# Altium schematic sheet [04] - POWER HARVESTING SYSTEM.SchDoc("[04] - POWER HARVESTING SYSTEM")
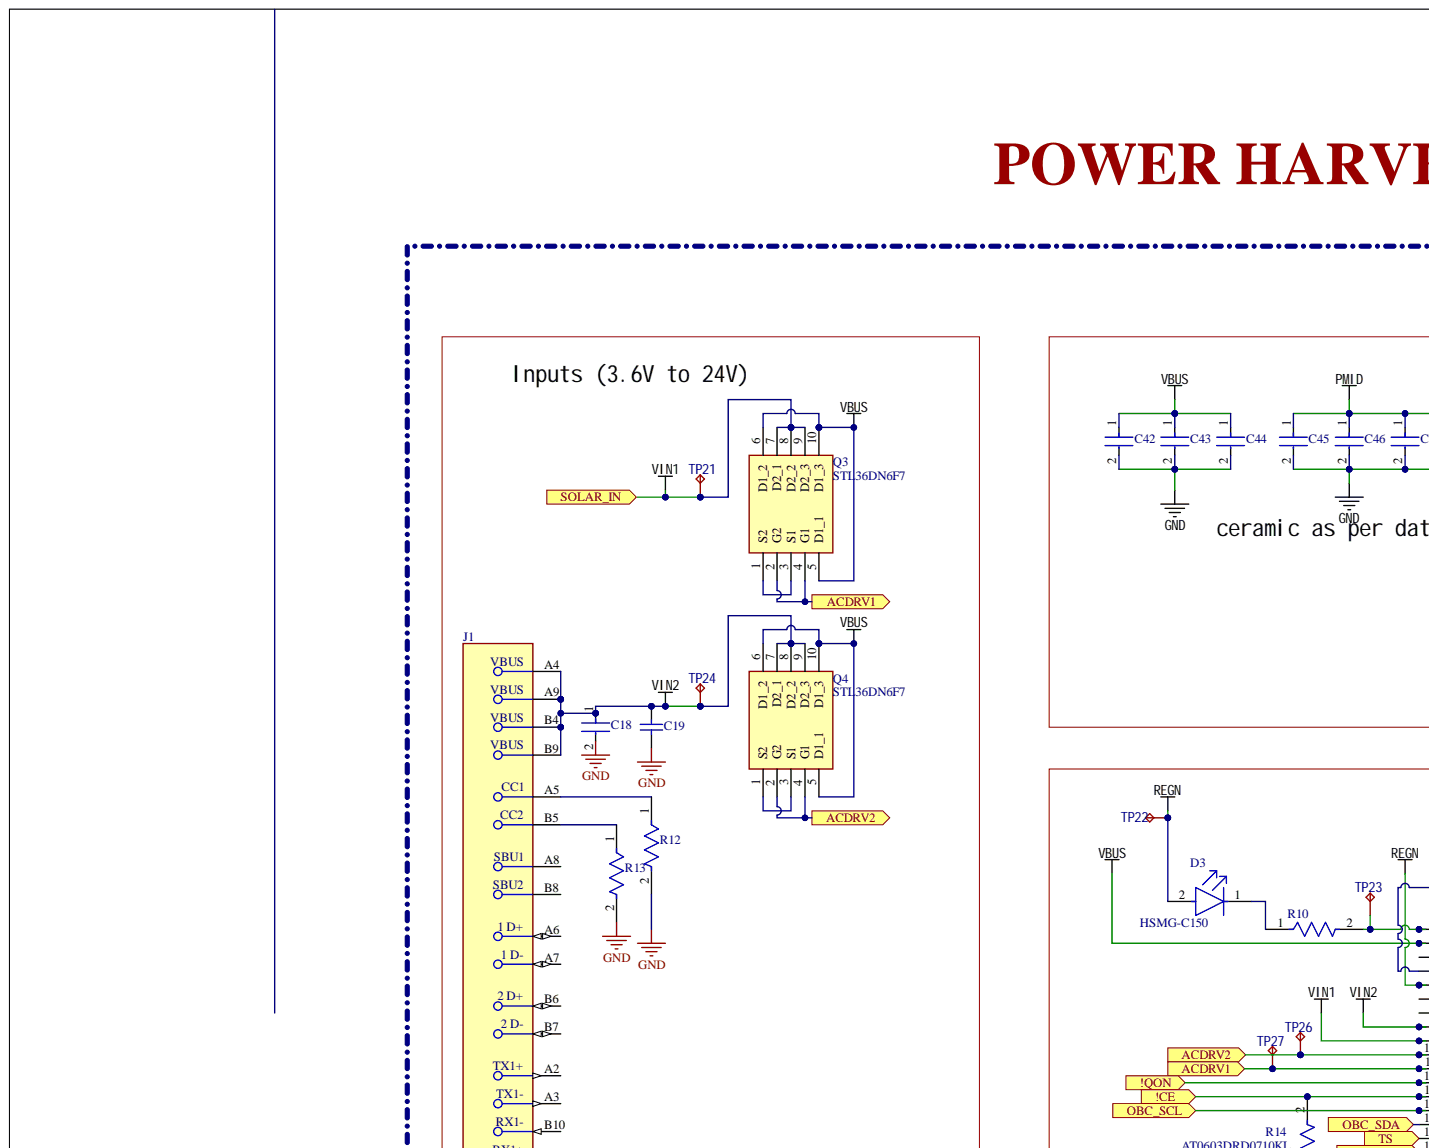
[diagram p0: [04] - POWER HARVESTING SYSTEM.SchDoc, top left]
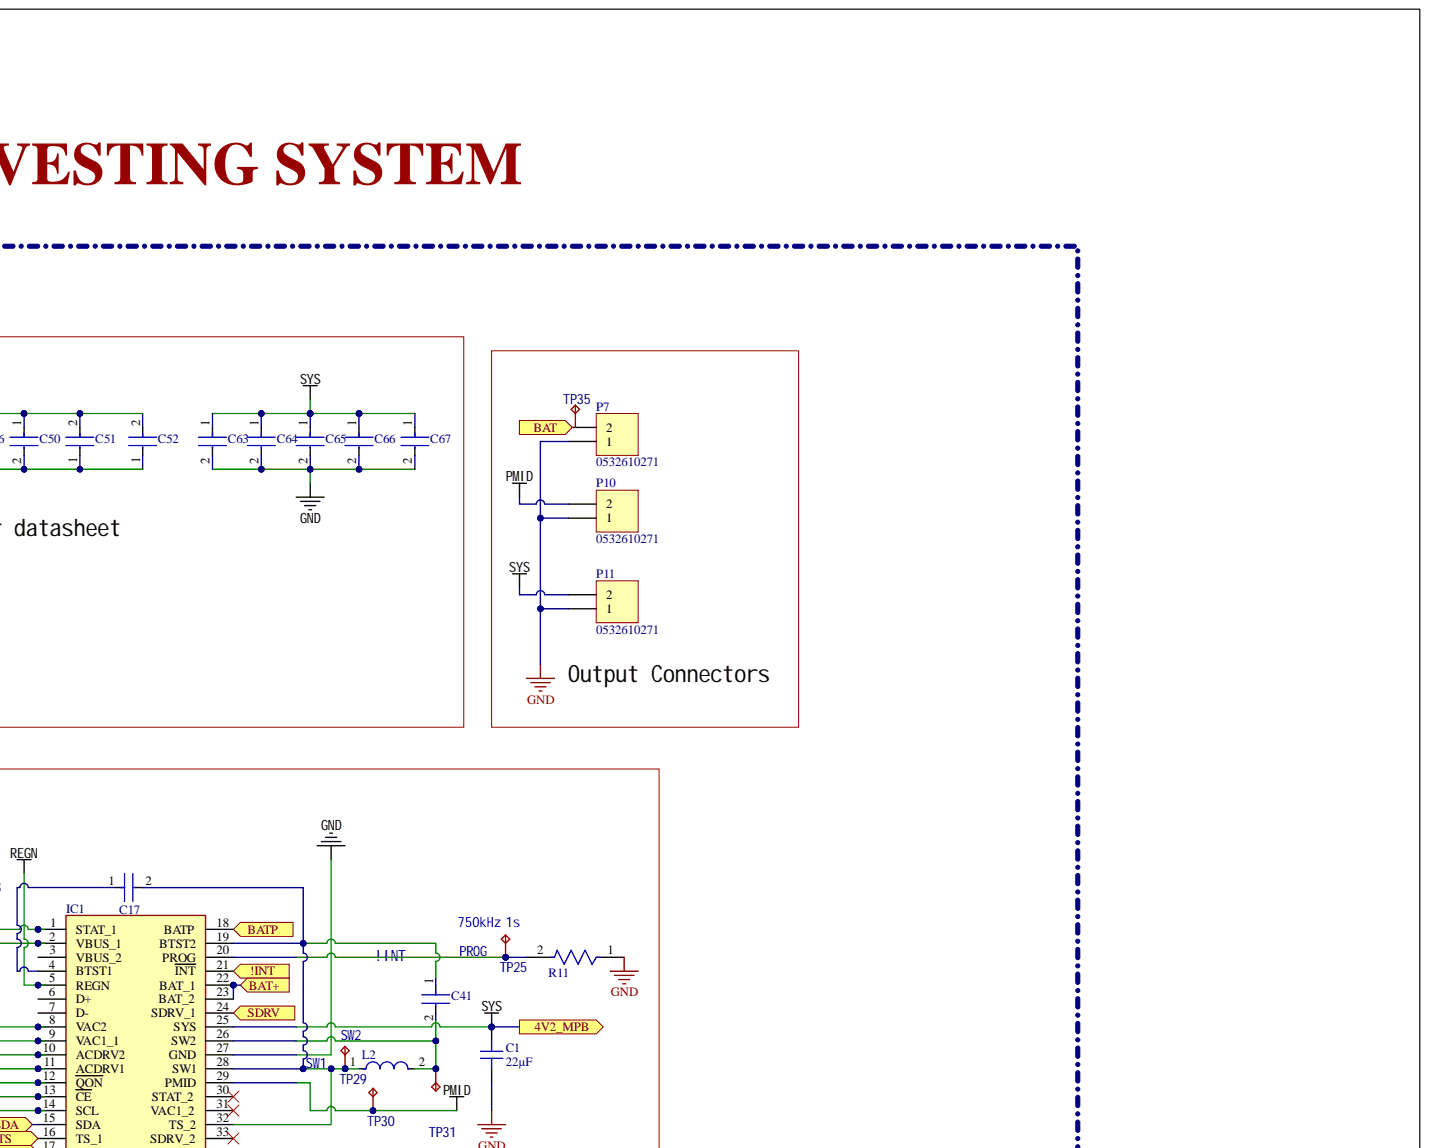
[diagram p1: [04] - POWER HARVESTING SYSTEM.SchDoc, top right]
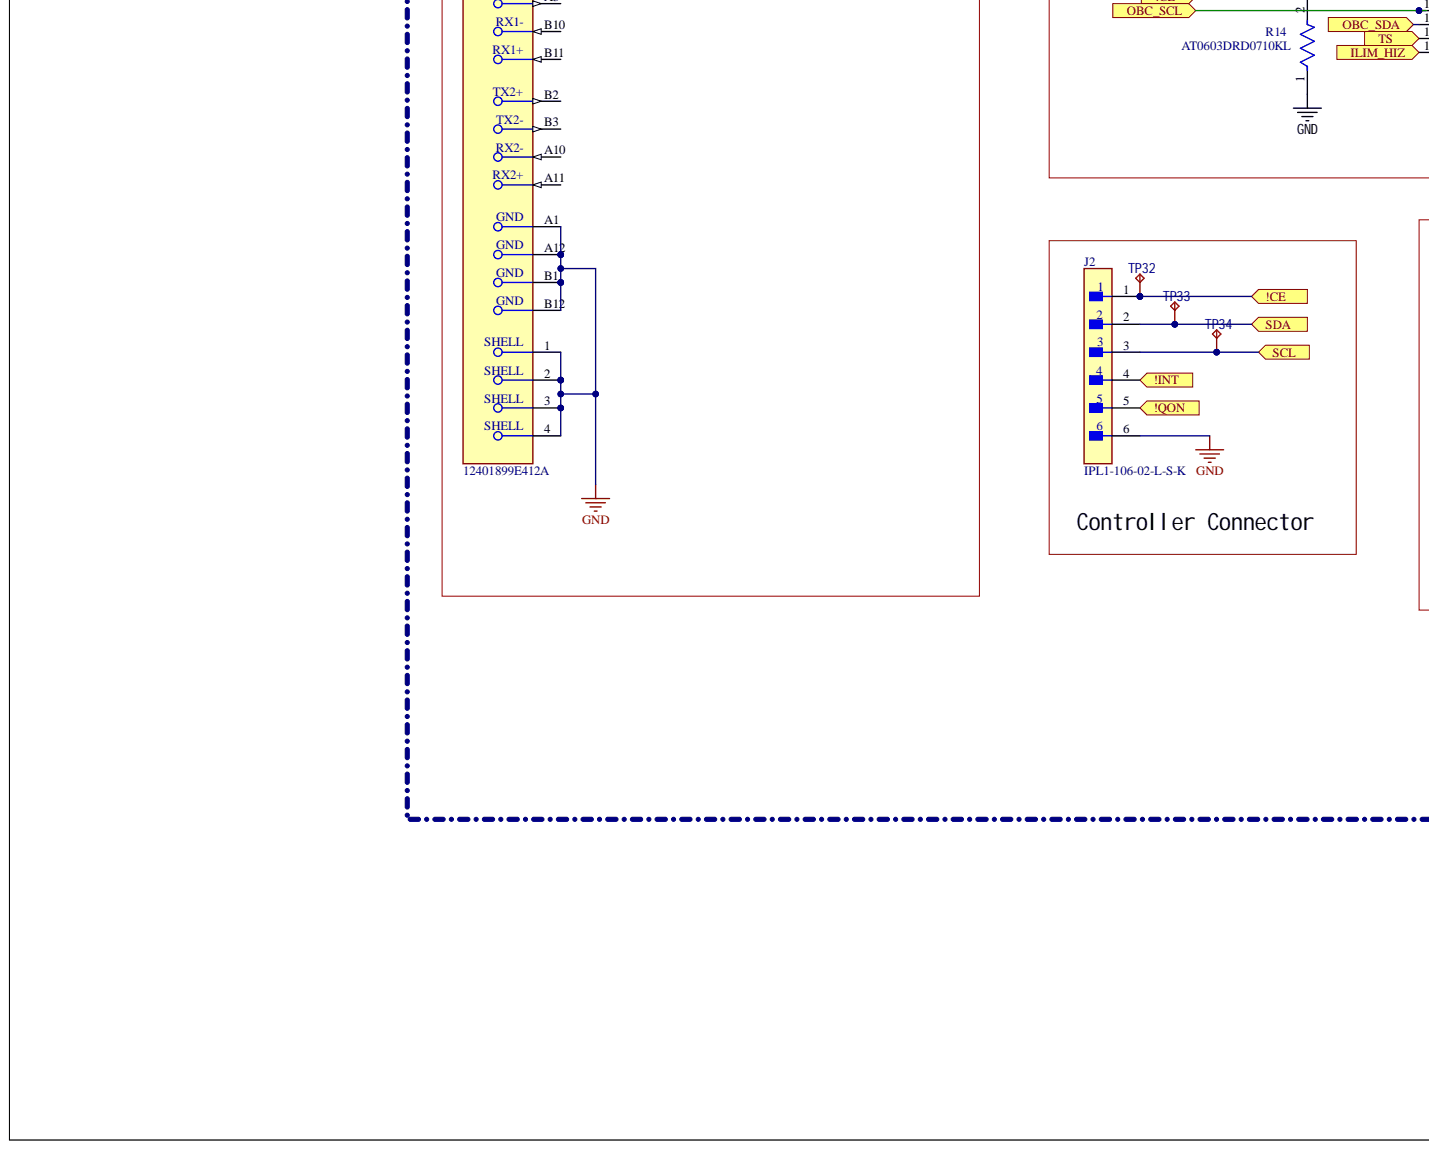
[diagram p2: [04] - POWER HARVESTING SYSTEM.SchDoc, bottom left]
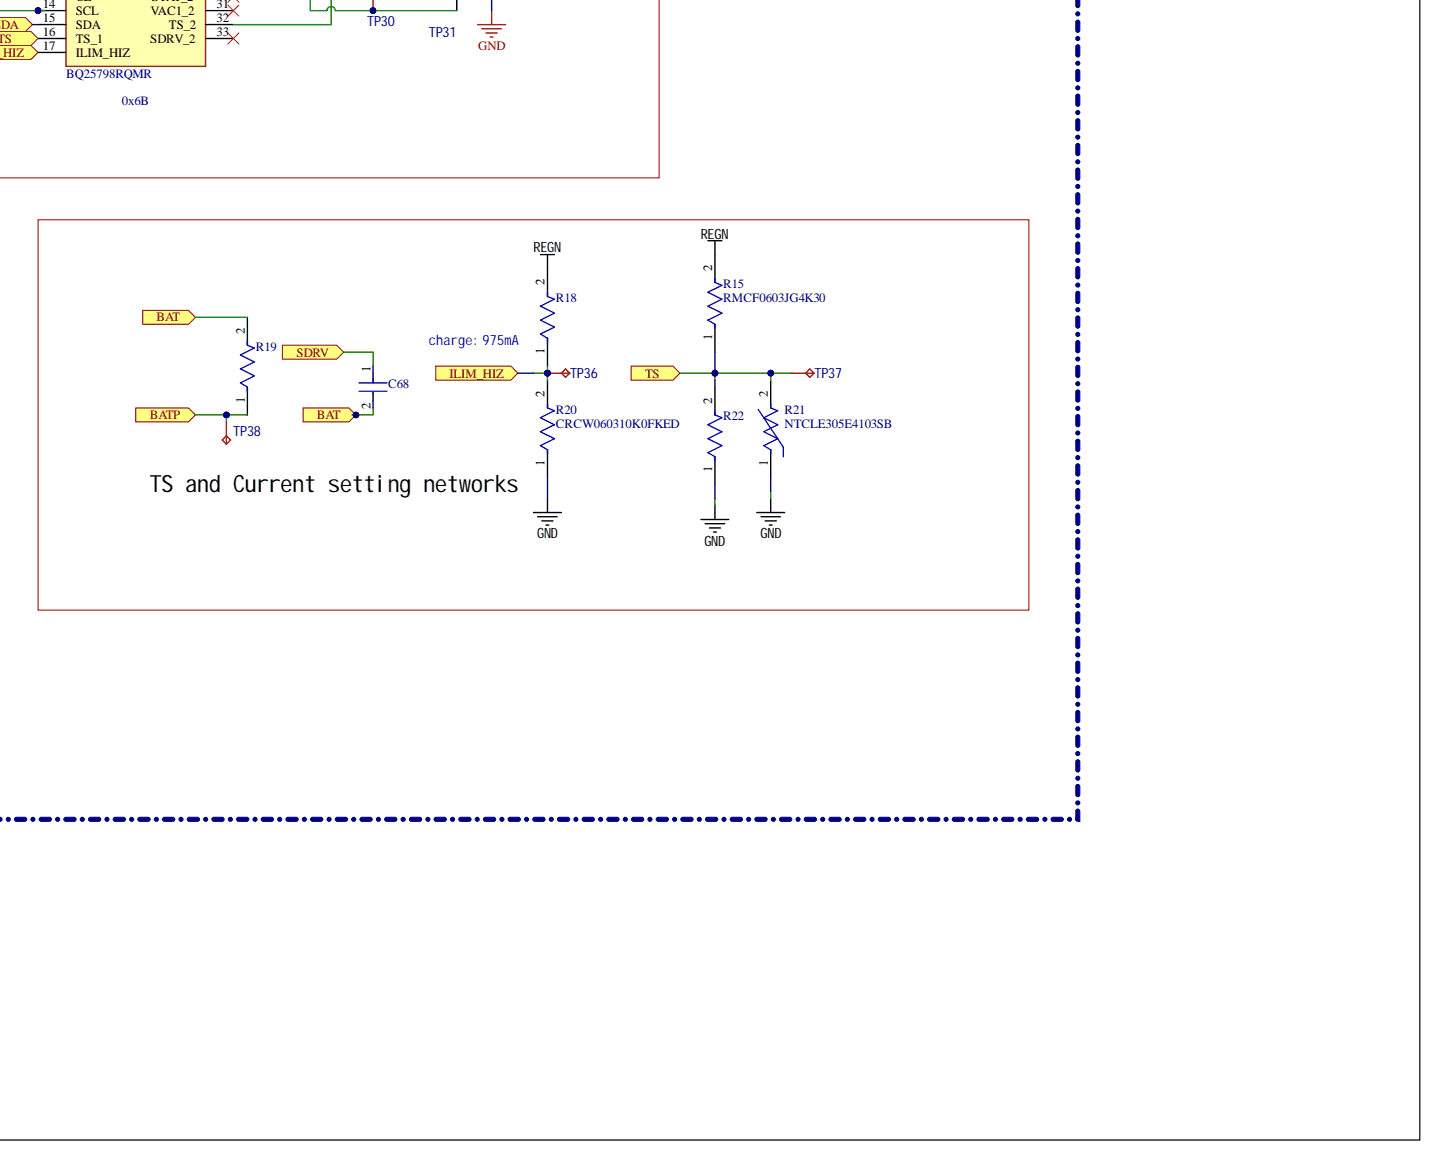
[diagram p3: [04] - POWER HARVESTING SYSTEM.SchDoc, bottom right]

## Parts
C1  Capacitance: 22µF  [CAP 0603/1608]  (hidden comment: GRM188R60J226MEA0D)
C17  CC0603KRX7R9BB473  [FP-CC0603-DA-MFG]  (value not drawn)
C18  ZRB15XC80G106ME05D  [FP-ZRB15X-0_22-MFG]  (value not drawn)
C19  C0603C104K4RALTU  [CAPC1608X87X35ML15T15]  (value not drawn)
C41  CC0603KRX7R9BB473  [FP-CC0603-DA-MFG]  (value not drawn)
C42  CL21A106KAYNNNE  [FP-CL21-IPC_A]  (value not drawn)
C43  CL21A106KAYNNNE  [FP-CL21-IPC_A]  (value not drawn)
C44  CC0603KRX7R9BB104  [FP-CC0603-DA-MFG]  (value not drawn)
C45  CL21A106KAYNNNE  [FP-CL21-IPC_A]  (value not drawn)
C46  CL21A106KAYNNNE  [FP-CL21-IPC_A]  (value not drawn)
C50  CC0603KRX7R9BB104  [FP-CC0603-DA-MFG]  (value not drawn)
C51  KRM55QR71E336KH01L  [FP-KRM55Q-6_1_5_3_3_9_1_2-MFG]  (value not drawn)
C52  KRM55QR71E336KH01L  [FP-KRM55Q-6_1_5_3_3_9_1_2-MFG]  (value not drawn)
C63  CL21A106KAYNNNE  [FP-CL21-IPC_A]  (value not drawn)
C64  CL21A106KAYNNNE  [FP-CL21-IPC_A]  (value not drawn)
C65  CL21A106KAYNNNE  [FP-CL21-IPC_A]  (value not drawn)
C66  CL21A106KAYNNNE  [FP-CL21-IPC_A]  (value not drawn)
C67  CC0603KRX7R9BB104  [FP-CC0603-DA-MFG]  (value not drawn)
C68  CC0603KRX7R9BB102  [FP-CC0603-DA-MFG]  (value not drawn)
D3  HSMG-C150  [FP-HSMG-C150-MFG]
IC1  BQ25798RQMR  [BQ25798RQMR]
J1  12401899E412A  [FP-12401899E412A-MFG]
J2  IPL1-106-02-L-S-K  [FP-IPL1-106-02-L-S-K-0]
L2  LQM21PN2R2MEHD  [FP-LQM21PN_EH-MFG]  (value not drawn)
P7  0532610271  [0532610271]
P10  0532610271  [0532610271]
P11  0532610271  [0532610271]
Q3  STL36DN6F7  [STL36DN6F7]
Q4  STL36DN6F7  [STL36DN6F7]
R10  RMCF0805JG2K00  [FP-RMCF0805-MFG]  (value not drawn)
R11  AT0603DRE074K7L  [FP-AT0603-IPC_C]  (value not drawn)
R12  MCR03EZPJ512  [FP-MCR03-IPC_B]  (value not drawn)
R13  MCR03EZPJ512  [FP-MCR03-IPC_B]  (value not drawn)
R14  AT0603DRD0710KL  [FP-AT0603-IPC_B]
R15  RMCF0603JG4K30  [FP-RMCF0603-IPC_A]
R18  RMCF0805JG18K0  [FP-RMCF0805-IPC_B]  (value not drawn)
R19  RMCF0805FG100R  [FP-RMCF0805-MFG]  (value not drawn)
R20  CRCW060310K0FKED  [FP-CRCW0603-e3-IPC_A]
R21  NTCLE305E4103SB  [FP-NTCLE305E4103SB-MFG]
R22  RMCF0805JG18K0  [FP-RMCF0805-IPC_B]  (value not drawn)
TP21  TP
TP22  TP
TP23  TP
TP24  TP
TP25  TP
TP26  TP
TP27  TP
TP29  TP
TP30  TP
TP31  TP
TP32  TP
TP33  TP
TP34  TP
TP35  TP
TP36  TP
TP37  TP
TP38  TP

## Ports
4V2_MPB, !CE, !INT, !QON, ACDRV1, ACDRV2, BAT, BAT+, BATP, ILIM_HIZ, OBC_SCL, OBC_SDA, SCL, SDA, SDRV, SOLAR_IN, TS

## Nets
GND: C1.1 C18.2 C19.1 C42.2 C43.2 C44.2 C45.2 C46.2 C50.2 C51.1 C52.1 C63.2 C64.2 C65.2 C66.2 C67.2 IC1.27(GND) J1.1 J1.2 J1.3 J1.4 J1.A1 J1.A12 J1.B1 J1.B12 J2.6 P7.1 P10.1 P11.1 R11.1 R12.2 R13.2 R14.1 R20.1 R21.1 R22.1
PMID: C45.1 C46.1 C50.1 C51.2 C52.2 IC1.29(PMID) P10.2 TP30.1
REGN: D3.2 IC1.5(REGN) R15.2 R18.2 TP22.1
VBUS: C42.1 C43.1 C44.1 IC1.2(VBUS_1) Q3.5(D1_1) Q3.6(D1_2) Q3.10(D1_3) Q4.5(D1_1) Q4.6(D1_2) Q4.10(D1_3)
VIN1: IC1.9(VAC1_1) Q3.7(D2_1) Q3.8(D2_2) Q3.9(D2_3) TP21.1
VIN2: C18.1 C19.2 IC1.8(VAC2) J1.A4 J1.A9 J1.B4 J1.B9 Q4.7(D2_1) Q4.8(D2_2) Q4.9(D2_3) TP24.1
!CE: IC1.13(CE) J2.1 R14.2 TP32.1 ; port !CE
!INT: IC1.21(INT) J2.4 ; port !INT
!QON: IC1.12(QON) J2.5 ; port !QON
ACDRV1: IC1.11(ACDRV1) Q3.2(G2) Q3.4(G1) TP27.1 ; port ACDRV1
ACDRV2: IC1.10(ACDRV2) Q4.2(G2) Q4.4(G1) TP26.1 ; port ACDRV2
BAT: C68.2 R19.2 ; port BAT
BAT+: IC1.22(BAT_1) IC1.23(BAT_2) ; port BAT+
BATP: IC1.18(BATP) R19.1 TP38.1 ; port BATP
ILIM_HIZ: IC1.17(ILIM_HIZ) R18.1 R20.2 TP36.1 ; port ILIM_HIZ
OBC_SCL: IC1.14(SCL) ; port OBC_SCL
OBC_SDA: IC1.15(SDA) ; port OBC_SDA
SCL: J2.3 TP34.1 ; port SCL
SDA: J2.2 TP33.1 ; port SDA
SDRV: C68.1 IC1.24(SDRV_1) ; port SDRV
SYS: C1.2 C63.1 C64.1 C65.1 C66.1 C67.1 IC1.25(SYS) P11.2 ; port 4V2_MPB
TS: IC1.16(TS_1) R15.1 R21.2 R22.2 TP37.1 ; port TS
NetC17_1: C17.1 IC1.4(BTST1)
NetC17_2: C17.2 C41.1 IC1.19(BTST2) IC1.28(SW1) IC1.32(TS_2) L2.1 TP29.1
NetC41_2: C41.2 IC1.26(SW2) L2.2 TP31.1
NetD3_1: D3.1 R10.1
NetIC1_1: IC1.1(STAT_1) R10.2 TP23.1
NetIC1_20: IC1.20(PROG) R11.2 TP25.1
NetJ1_A5: J1.A5 R12.1
NetJ1_B5: J1.B5 R13.1
NetQ3_1: Q3.1(S2) Q3.3(S1)
NetQ4_1: Q4.1(S2) Q4.3(S1)
!INT:
N00001: ; port SOLAR_IN
PROG:
SW1:
SW2:

Not connected: IC1 3(VBUS_2) 6(D+) 7(D-) 30(STAT_2) 31(VAC1_2) 33(SDRV_2); J1 A2 A3 A6 A7 A8 A10 A11 B2 B3 B6 B7 B8 B10 B11; P7 2; TP35 1

## Library data of the parts
C1 = GRM188R60J226MEA0D: CAP CER 22UF 6.3V X5R 0603; Manufacturer: Murata; Tolerance: 20%; Case Code (Imperial): 0603; Case Code (Metric): 1608; Case/Package: 0603; Component Kind: Standard; ComponentLink1Description: https://datasheet.ciiva.com/29540/partnumbering-e-01-29540441.pdf?src-supplier=Digi-Key; ComponentLink1URL: https://datasheet.ciiva.com/29540/partnumbering-e-01-29540441.pdf?src-supplier=Digi-Key; ComponentLink2Description: https://datasheet.ciiva.com/29540/partnumbering-e-01-29540442.pdf?src-supplier=Digi-Key; ComponentLink2URL: https://datasheet.ciiva.com/29540/partnumbering-e-01-29540442.pdf?src-supplier=Digi-Key; ComponentLink3Description: https://datasheet.ciiva.com/29540/partnumbering-e-01-29540443.pdf?src-supplier=Digi-Key; ComponentLink3URL: https://datasheet.ciiva.com/29540/partnumbering-e-01-29540443.pdf?src-supplier=Digi-Key; Depth: 0.8mm; Dielectric: X5R; Height: 0.8mm; Lead Free: Lead Free; Length: 1.6mm; Manufacturer Part Number: GRM188R60J226MEA0D; Max Operating Temperature: 85°C; Min Operating Temperature: -55°C; Mount: Surface Mount; Package Quantity: 4000; Packaging: Tape and Reel; Pricing 1: 1 = 0.26000, 10 = 0.17700, 100 = 0.10010, 500 = 0.07066, 1000 = 0.06182 (USD); REACH SVHC: No SVHC; RoHS: Yes; Stock 1: 6592419; Supplier 1: Digi-Key; Supplier Part Number 1: 490-7611-1-ND; Termination: SMD/SMT; Thickness: 0.039inch; Voltage Rating: 6.3V; Voltage Rating (DC): 6.3V; Weight: 0.000222oz; Width: 0.8mm; Library Name: UVG.IntLib
C17 C41 = CMP-2100-03604-2: CAP CER 0.047UF 50V X7R 0603; Manufacturer: YAGEO; Capacitance: 47 nF; Material: Ceramic; Temperature Coefficient: 15%; Tolerance: 0.1; Value: 47 nF; Automotive: No; Category: Cap; Component Kind: Standard; ComponentLink2Description: Datasheet; ComponentLink2URL: http://www.yageo.com/documents/recent/UPY-GPHC_X7R_6.3V-to-50V_18.pdf; Device Class L1: Passive Components; Device Class L2: Capacitors; Device Class L3: Ceramic Capacitors; Digikey Description: CAP CER 0.047UF 50V X7R 0603; Footprint Url: http://www.yageo.com/exep/pages/download/literatures/UPY-C_GEN_24.pdf; Height: 0.9mm; Lead Free: Yes; Library Name: Altium Content Vault; Manufacturer Part Number: CC0603KRX7R9BB473; Mouser Description: Multilayer Ceramic Capacitors MLCC - SMD/SMT .047UF 50V 10% 0603; Octopart: https://octopart.com/cc0603krx7r9bb473-yageo-9292680; Package: CC0603-DA; RoHS: TRUE; Temperature: -55°C to +125°C; Temperature Characteristic: X7R; Temperature Range High: +125°C; Temperature Range Low: -55°C; Voltage: 50 V; Voltage Rating: 50 V
C18 = CMP-06035-063245-1: Multilayer Ceramic Capacitors on Interposer Board for General Purpose 10μF ±20% 4VDC X6S  SMD  0402; Component Kind: Standard; Library Name: Altium Content Vault
C19 = CMP-2006-03059-1: Manufacturer: Kemet; Component Kind: Standard; Library Name: Altium Content Vault; Part Number: C0603C104K4RALTU
C42 C43 C45 C46 C63-C66 = CMP-13271-002975-1: CL21 Series 0805 10uF 25V ±10% Tolerance X5R Multilayer Ceramic Chip Capacitor; Capacitance: 10uF; Case Code (Imperial): 0805; Case Code (Metric): 2012; Case/Package: 0805; Component Kind: Standard; Depth: 1.25mm; Dielectric: X5R; Height: 1.25mm; Length: 2mm; Library Name: Altium Content Vault; Max Operating Temperature: 85°C; Min Operating Temperature: -55°C; Mount: Surface Mount; Package Quantity: 1; Packaging: Tape and Reel; Radiation Hardening: No; Thickness: 0.057inch; Tolerance: 10%; Voltage Rating: 25V; Width: 0.049inch
C44 C50 C67 = CMP-2100-03601-2: CAP CER 0.1UF 50V X7R 0603; Manufacturer: YAGEO; Capacitance: 100 nF; Material: Ceramic; Temperature Coefficient: 15%; Tolerance: 0.1; Value: 100 nF; Automotive: No; Category: Cap; Component Kind: Standard; ComponentLink2Description: Datasheet; ComponentLink2URL: http://www.yageo.com/documents/recent/UPY-GPHC_X7R_6.3V-to-50V_18.pdf; Device Class L1: Passive Components; Device Class L2: Capacitors; Device Class L3: Ceramic Capacitors; Digikey Description: CAP CER 0.1UF 50V X7R 0603; Footprint Url: http://www.yageo.com/exep/pages/download/literatures/UPY-C_GEN_24.pdf; Height: 0.9mm; Lead Free: Yes; Library Name: Altium Content Vault; Manufacturer Part Number: CC0603KRX7R9BB104; Mouser Description: Multilayer Ceramic Capacitor, CC Series, 0.1 - F, - 10%, X7R, 50 V, 0603 [1608 Metric]; Octopart: https://octopart.com/cc0603krx7r9bb104-yageo-42244635; Package: CC0603-DA; RoHS: TRUE; Temperature: -55°C to +125°C; Temperature Characteristic: X7R; Temperature Range High: +125°C; Temperature Range Low: -55°C; Voltage: 50 V; Voltage Rating: 50 V
C51 C52 = CMP-06035-051390-1: Cap Ceramic 33uF 25V X7R ±10% SMD 2220 +125°C Emboss T/R; Component Kind: Standard; Library Name: Altium Content Vault
C68 = CMP-2100-03599-2: CAP CER 1000PF 50V X7R 0603; Manufacturer: YAGEO; Capacitance: 1.0 nF; Material: Ceramic; Temperature Coefficient: 15%; Tolerance: 0.1; Value: 1.0 nF; Automotive: No; Category: Cap; Component Kind: Standard; ComponentLink2Description: Datasheet; ComponentLink2URL: http://www.yageo.com/documents/recent/UPY-GPHC_X7R_6.3V-to-50V_18.pdf; Device Class L1: Passive Components; Device Class L2: Capacitors; Device Class L3: Ceramic Capacitors; Digikey Description: CAP CER 1000PF 50V X7R 0603; Footprint Url: http://www.yageo.com/exep/pages/download/literatures/UPY-C_GEN_24.pdf; Height: 0.9mm; Lead Free: Yes; Library Name: Altium Content Vault; Manufacturer Part Number: CC0603KRX7R9BB102; Mouser Description: Multilayer Ceramic Capacitors MLCC - SMD/SMT 1.0nF 50V X7R 10%; Octopart: https://octopart.com/cc0603krx7r9bb102-yageo-192933; Package: CC0603-DA; RoHS: TRUE; Temperature: -55°C to +125°C; Temperature Characteristic: X7R; Temperature Range High: +125°C; Temperature Range Low: -55°C; Voltage: 50 V; Voltage Rating: 50 V
D3 = CMP-2000-05061-2: LED GREEN DIFFUSED 1206 SMD; Manufacturer: Broadcom; Forward Current: 20mA; Forward Voltage: 2.2V; Led Orientation: Top View; Luminous Intensity: 15mcd; Max Junction Temp: +95°C; Peak Emmision Wavelength: 570nm; Power Dissipation: 65mW; Reverse Voltage: 5V; Viewing Angle: 170°; Wavelength: 572nm; Automotive: No; Category: Diode; Colour: Green; Component Kind: Standard; ComponentLink2Description: Datasheet; ComponentLink2URL: https://docs.broadcom.com/docs/AV02-0551EN; Device Class L1: Optoelectronics; Device Class L2: LEDs; Device Class L3: unset; Digikey Description: LED GREEN DIFFUSED 1206 SMD; Height: 1.2mm; Ipc Land Pattern Name: DIOCP320160X55; Lead Free: Yes; Library Name: Altium Content Vault; Manufacturer Part Number: HSMG-C150; Mouser Description: LED Uni-Color Green 570nm 2-Pin Chip LED T/R; Octopart: https://octopart.com/hsmg-c150-broadcom-71131304; Package: HSMG-C150; RoHS: TRUE; Temperature: -40°C to +85°C; Temperature Range High: +85°C; Temperature Range Low: -40°C
IC1 = BQ25798RQMR: Integrated Circuit; Manufacturer_Name: Texas Instruments; Arrow Part Number: BQ25798RQMR; Arrow Price/Stock: https://www.arrow.com/en/products/bq25798rqmr/texas-instruments?utm_currency=USD&region=nac; Component Kind: Standard; Datasheet Link: https://www.ti.com/lit/gpn/bq25798; Height: 1mm; Manufacturer_Part_Number: BQ25798RQMR; Mouser Part Number: 595-BQ25798RQMR; Mouser Price/Stock: https://www.mouser.co.uk/ProductDetail/Texas-Instruments/BQ25798RQMR?qs=ljCeji4nMDmr66dwAbNsCg%3D%3D
J1 = CMP-02355-000006-1: USB TYPE C, RECEPTACLE, TOP MOUN; Component Kind: Standard; Library Name: Altium Content Vault
J2 = CMP-02766-000454-1: 6 Position 1 Row .100" (2.54 mm) pitch connector; Gender: Male; Number of Contacts: 6; Pitch: 2.54mm; Component Kind: Standard; Library Name: Altium Content Vault; Mount: Surface Mount; Orientation: Straight; Packaging: Bulk; Radiation Hardening: No; Termination: Solder
L2 = CMP-06042-012634-1: FIXED IND 2.2UH 1.1A 219 MOHM; Core Material: Ferrite; DC Resistance (DCR): 219mR; Saturation Current: 450mA; Self Resonant Frequency: 30MHz; Shielding: Shielded; Test Frequency: 1MHz; Tolerance: 20%; Case Code (Imperial): 0805; Case Code (Metric): 2012; Case/Package: 0805; Component Kind: Standard; Current Rating: 1.1A; Inductance: 2.2uH; Library Name: Altium Content Vault; Max Operating Temperature: 85°C; Min Operating Temperature: -40°C; Mount: Surface Mount; Packaging: Cut Tape
P7 P10 P11 = 0532610271: CONN HEADER 2POS 1.25MM R/A SMD; Manufacturer: Molex; Manufacturer Part Number: 53261-0271; Contact Resistance: 20mR; Current Rating: 1A; Gender: Male; Glow Wire Compliant: Non-compliant; Insulation Resistance: 100MR; Number of Circuits: 2; Voltage Rating: 125V; Color: Beige; Component Kind: Standard; ComponentLink1Description: https://datasheet.ciiva.com/11747/0900766b8078fc90-11747475.pdf?src-supplier=RSComponents; ComponentLink1URL: https://datasheet.ciiva.com/11747/0900766b8078fc90-11747475.pdf?src-supplier=RSComponents; ComponentLink2Description: https://datasheet.ciiva.com/5151/0532610271-pcb-headers-171053-5151611.pdf?src-supplier=Mouser; ComponentLink2URL: https://datasheet.ciiva.com/5151/0532610271-pcb-headers-171053-5151611.pdf?src-supplier=Mouser; ComponentLink3Description: https://datasheet.ciiva.com/7167/1626147-7167496.pdf?src-supplier=Newark; ComponentLink3URL: https://datasheet.ciiva.com/7167/1626147-7167496.pdf?src-supplier=Newark; ComponentLink4Description: https://datasheet.ciiva.com/30193/198270532610271-pcb-headers-30193321.pdf?src-supplier=Onlinecomponents.com; ComponentLink4URL: https://datasheet.ciiva.com/30193/198270532610271-pcb-headers-30193321.pdf?src-supplier=Onlinecomponents.com; ComponentLink5Description: https://datasheet.ciiva.com/34303/1932547-34303342.pdf?src-supplier=Jameco; ComponentLink5URL: https://datasheet.ciiva.com/34303/1932547-34303342.pdf?src-supplier=Jameco; ComponentLink6Description: https://datasheet.ciiva.com/16658/0532610271-pcb-headers-16658423.pdf?src-supplier=Avnet+Europe; ComponentLink6URL: https://datasheet.ciiva.com/16658/0532610271-pcb-headers-16658423.pdf?src-supplier=Avnet+Europe; ComponentLink7Description: https://datasheet.ciiva.com/17035/70091115-17035863.pdf?src-supplier=Allied+Electronics; ComponentLink7URL: https://datasheet.ciiva.com/17035/70091115-17035863.pdf?src-supplier=Allied+Electronics; ComponentLink8Description: https://datasheet.ciiva.com/46393/1808526-46393547.pdf?src-supplier=Element14; ComponentLink8URL: https://datasheet.ciiva.com/46393/1808526-46393547.pdf?src-supplier=Element14; ComponentLink9Description: https://datasheet.ciiva.com/7920/1887027-7920826.pdf?src-supplier=Element14; ComponentLink9URL: https://datasheet.ciiva.com/7920/1887027-7920826.pdf?src-supplier=Element14; ComponentLink10Description: https://datasheet.ciiva.com/9468/0532610271-pcb-headers-9468506.pdf?src-supplier=Avnet; ComponentLink10URL: https://datasheet.ciiva.com/9468/0532610271-pcb-headers-9468506.pdf?src-supplier=Avnet; ComponentLink11Description: https://datasheet.ciiva.com/22683/1626147-22683562.pdf?src-supplier=Element14; ComponentLink11URL: https://datasheet.ciiva.com/22683/1626147-22683562.pdf?src-supplier=Element14; Contact Material: Bronze; Contact Plating: Tin; Flammability Rating: UL94 V-0; Housing Material: Thermoplastic; Lead Free: Lead Free; Lead Pitch: 1.25mm; Max Operating Temperature: 85°C; Min Operating Temperature: -40°C; Mount: Surface Mount; Number of Contacts: 2; Number of Positions: 2; Number of Rows: 1; Orientation: Right Angle; Package Quantity: 1000; Packaging: Tape and Reel; Pitch: 1.25mm; Pricing 1: 1 = 0.98000, 10 = 0.86000, 100 = 0.74170, 500 = 0.60688 (USD); Radiation Hardening: No; REACH SVHC: Unknown; RoHS: Yes; Stock 1: 34859; Supplier 1: Digi-Key; Supplier Part Number 1: WM7620CT-ND; Termination: SMD/SMT; Voltage Rating (AC): 125V; Voltage Rating (DC): 125V; Working Voltage: 125V; Library Name: UVG.IntLib
Q3 Q4 = STL36DN6F7: MOSFET (N-Channel); Manufacturer_Name: STMicroelectronics; Arrow Part Number: STL36DN6F7; Arrow Price/Stock: https://www.arrow.com/en/products/stl36dn6f7/stmicroelectronics; Component Kind: Standard; Datasheet Link: https://www.st.com/resource/en/datasheet/stl36dn6f7.pdf; Manufacturer_Part_Number: STL36DN6F7; Mouser Part Number: 511-STL36DN6F7; Mouser Price/Stock: https://www.mouser.co.uk/ProductDetail/STMicroelectronics/STL36DN6F7/?qs=u4fy%2FsgLU9MwLM2KeqBprA%3D%3D
R10 = CMP-26527-001989-1: 2kΩ ±5% 0.125W 0805 Thick Film Chip Resistor AEC-Q200 compliant; Max Power Dissipation: 125mW; Resistance: 2kR; Temperature Coefficient: 200ppm/°C; Case/Package: 0805; Component Kind: Standard; Contact Plating: Tin; Features: Automotive AEC-Q200; Height: 0.026inch; Length: 0.079inch; Library Name: Altium Content Vault; Max Operating Temperature: 155°C; Min Operating Temperature: -55°C; Mount: Surface Mount; Number of Terminations: 2; Package Quantity: 10000; Packaging: Tape and Reel; Radiation Hardening: No; Resistor Type: Thick Film; RoHS Compliant: Yes; Series: RMCF; Tolerance: 5%; Width: 0.049inch
R11 = CMP-03412-017153-2: Thin Film Chip Resistors Automotive Grade 4.7kΩ 0.5% 50ppm/°C 0603; Max Power Dissipation: 100mW; Resistance: 4.7kR; Case/Package: 0603; Component Kind: Standard; Features: Moisture Resistant; Height: 0.022inch; Length: 0.063inch; Library Name: Altium Content Vault; Max Operating Temperature: 155°C; Min Operating Temperature: -55°C; Number of Terminations: 2; Packaging: Tape and Reel; Resistor Type: Thin Film; RoHS Compliant: Yes; Series: AT; Temperature Coefficient: 50ppm/°C; Tolerance: 0.5%; Width: 0.032inch
R12 R13 = CMP-08839-027005-1: Thick Film Chip Resistor, 0603, 5.1kΩ, 5%, 200ppm/°C, 0.1W, 50V; Max Power Dissipation: 100mW; Resistance: 5.1kR; Case Code (Imperial): 0603; Case Code (Metric): 1608; Case/Package: 0603; Component Kind: Standard; Contact Plating: Tin; Features: Automotive AEC-Q200; Height: 0.022inch; Lead Free: Lead Free; Length: 0.063inch; Library Name: Altium Content Vault; Max Operating Temperature: 155°C; Min Operating Temperature: -55°C; Mount: Surface Mount; Number of Terminations: 2; Package Quantity: 5000; Packaging: Tape and Reel; Power Rating: 100mW; Resistor Type: Thick Film; RoHS Compliant: Yes; Series: MCR; Technology: THICK FILM; Temperature Coefficient: 200ppm/°C; Tolerance: 5%; Voltage Rating (DC): 50V; Width: 0.031inch; Working Voltage: 50V
R14 = CMP-03412-020422-2: Thin Film Chip Resistors Automotive Grade 10kΩ 0.5% 25ppm/°C 0603; Max Power Dissipation: 100mW; Resistance: 10kR; Case Code (Imperial): 0603; Case Code (Metric): 1608; Case/Package: 0603; Component Kind: Standard; Features: Moisture Resistant; Height: 0.022inch; Length: 0.063inch; Library Name: Altium Content Vault; Max Operating Temperature: 155°C; Min Operating Temperature: -55°C; Number of Terminations: 2; Packaging: Cut Tape; Ratings: AEC-Q200; Resistor Type: Thin Film; RoHS Compliant: Yes; Series: AT; Temperature Coefficient: 25ppm/°C; Tolerance: 0.5%; Width: 0.032inch
R15 = CMP-26527-004826-1: 4.3kΩ ±5% 0.1W 0603 Thick Film Chip Resistor AEC-Q200 compliant; Max Power Dissipation: 100mW; Resistance: 4.3kR; Temperature Coefficient: 200ppm/°C; Case/Package: 0603; Component Kind: Standard; Features: Automotive AEC-Q200; Height: 0.022inch; Length: 0.061inch; Library Name: Altium Content Vault; Max Operating Temperature: 155°C; Min Operating Temperature: -55°C; Number of Terminations: 2; Packaging: Tape and Reel; Resistor Type: Thick Film; RoHS Compliant: Yes; Series: RMCF; Tolerance: 5%; Width: 0.031inch
R18 R22 = CMP-26527-005194-1: 18kΩ ±5% 0.125W 0805 Thick Film Chip Resistor AEC-Q200 compliant; Resistance: 18kR; Temperature Coefficient: 100ppm/°C; Case/Package: 0805; Component Kind: Standard; Contact Plating: Tin; Library Name: Altium Content Vault; Max Operating Temperature: 155°C; Min Operating Temperature: -55°C; Mount: Surface Mount; Packaging: Tape and Reel; Radiation Hardening: No; Resistor Type: Thick Film; RoHS Compliant: Yes; Tolerance: 5%
R19 = CMP-26527-002994-1: 100Ω ±1% 0.125W 0805 Thick Film Chip Resistor AEC-Q200 compliant; Max Power Dissipation: 125mW; Resistance: 100R; Temperature Coefficient: 100ppm/°C; Case Code (Imperial): 0805; Case Code (Metric): 2012; Case/Package: 0805; Component Kind: Standard; Contact Plating: Tin; Features: Automotive AEC-Q200; Height: 0.026inch; Length: 0.079inch; Library Name: Altium Content Vault; Max Operating Temperature: 155°C; Min Operating Temperature: -55°C; Mount: Surface Mount; Number of Terminations: 2; Package Quantity: 10000; Packaging: Tape and Reel; Radiation Hardening: No; Resistor Type: Thick Film; RoHS Compliant: Yes; Series: RMCF; Tolerance: 1%; Width: 0.049inch
R20 = CMP-02407-003273-1: RES Thick Film, 10kΩ, 1%, 0.1W, 100ppm/°C, 0603; Max Power Dissipation: 100mW; Resistance: 10kR; Case/Package: 0603; Component Kind: Standard; Composition: Thick Film; Features: Automotive AEC-Q200; Height: 0.02inch; Lead Free: Lead Free; Length: 0.061inch; Library Name: Altium Content Vault; Max Operating Temperature: 155°C; Min Operating Temperature: -55°C; Number of Terminations: 2; Package Quantity: 10000; Packaging: Tape and Reel; Temperature Coefficient: 100ppm/°C; Tolerance: 1%; Width: 0.033inch
R21 = CMP-02404-000012-1: THERMISTOR NTC 10KOHM 3984K BEAD; Accuracy: 0.5%; Max Power Dissipation: 50mW; Resistance: 10kR; Resistance Tolerance: 2.2%; Case/Package: Radial; Component Kind: Standard; Contact Plating: Silver; Library Name: Altium Content Vault; Max Operating Temperature: 125°C; Min Operating Temperature: -40°C; Mount: Through Hole; Number of Pins: 2; Package Quantity: 1000; Packaging: Bulk; Radiation Hardening: No; RoHS Compliant: Yes; Series: NTCLE305E4...SB; Termination: Radial; Tolerance: 2.2%
TP21-TP27 TP29-TP38 = TP: Add into BOM: no; Contributor: Clash Crafter 6; Convert to PCB: yes; Component Kind: Standard; Device: TP; Origin Footprint: TP; spicePre: T; spiceSymbolName: TP; Symbol: TP
